# Altium schematic sheet 5V_SYS_SUPPLY.SchDoc
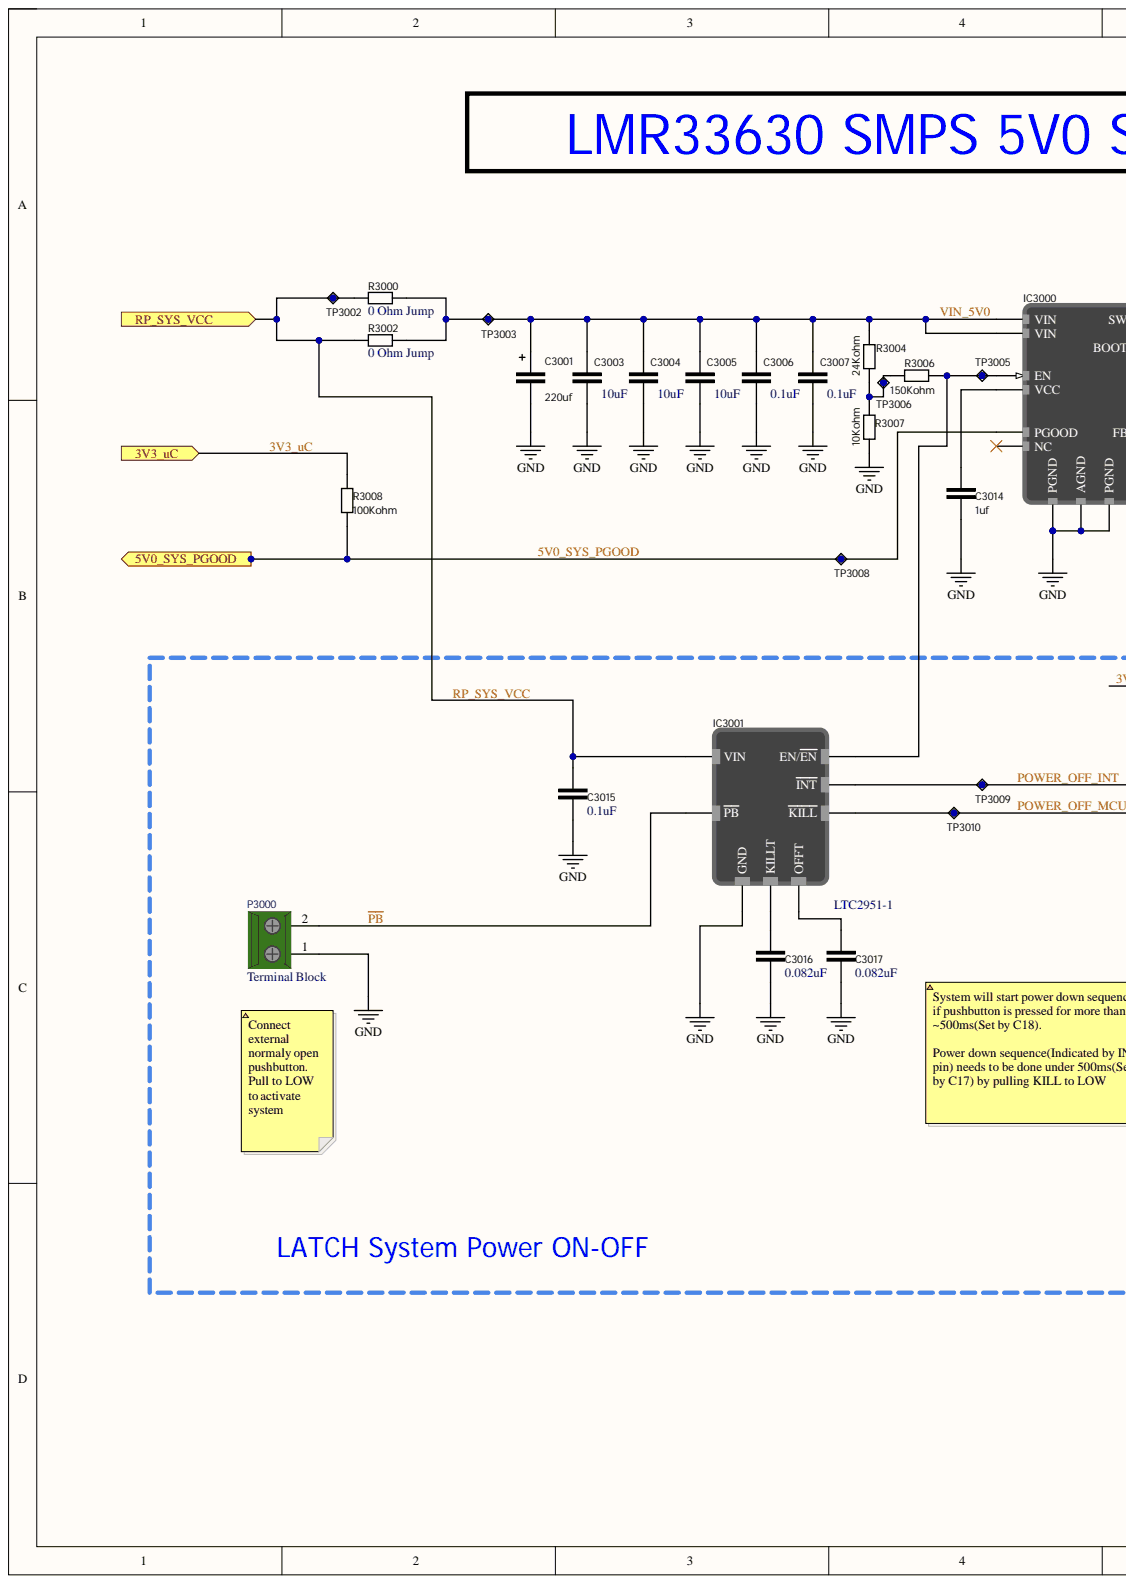
[diagram p0: 5V_SYS_SUPPLY.SchDoc, left]
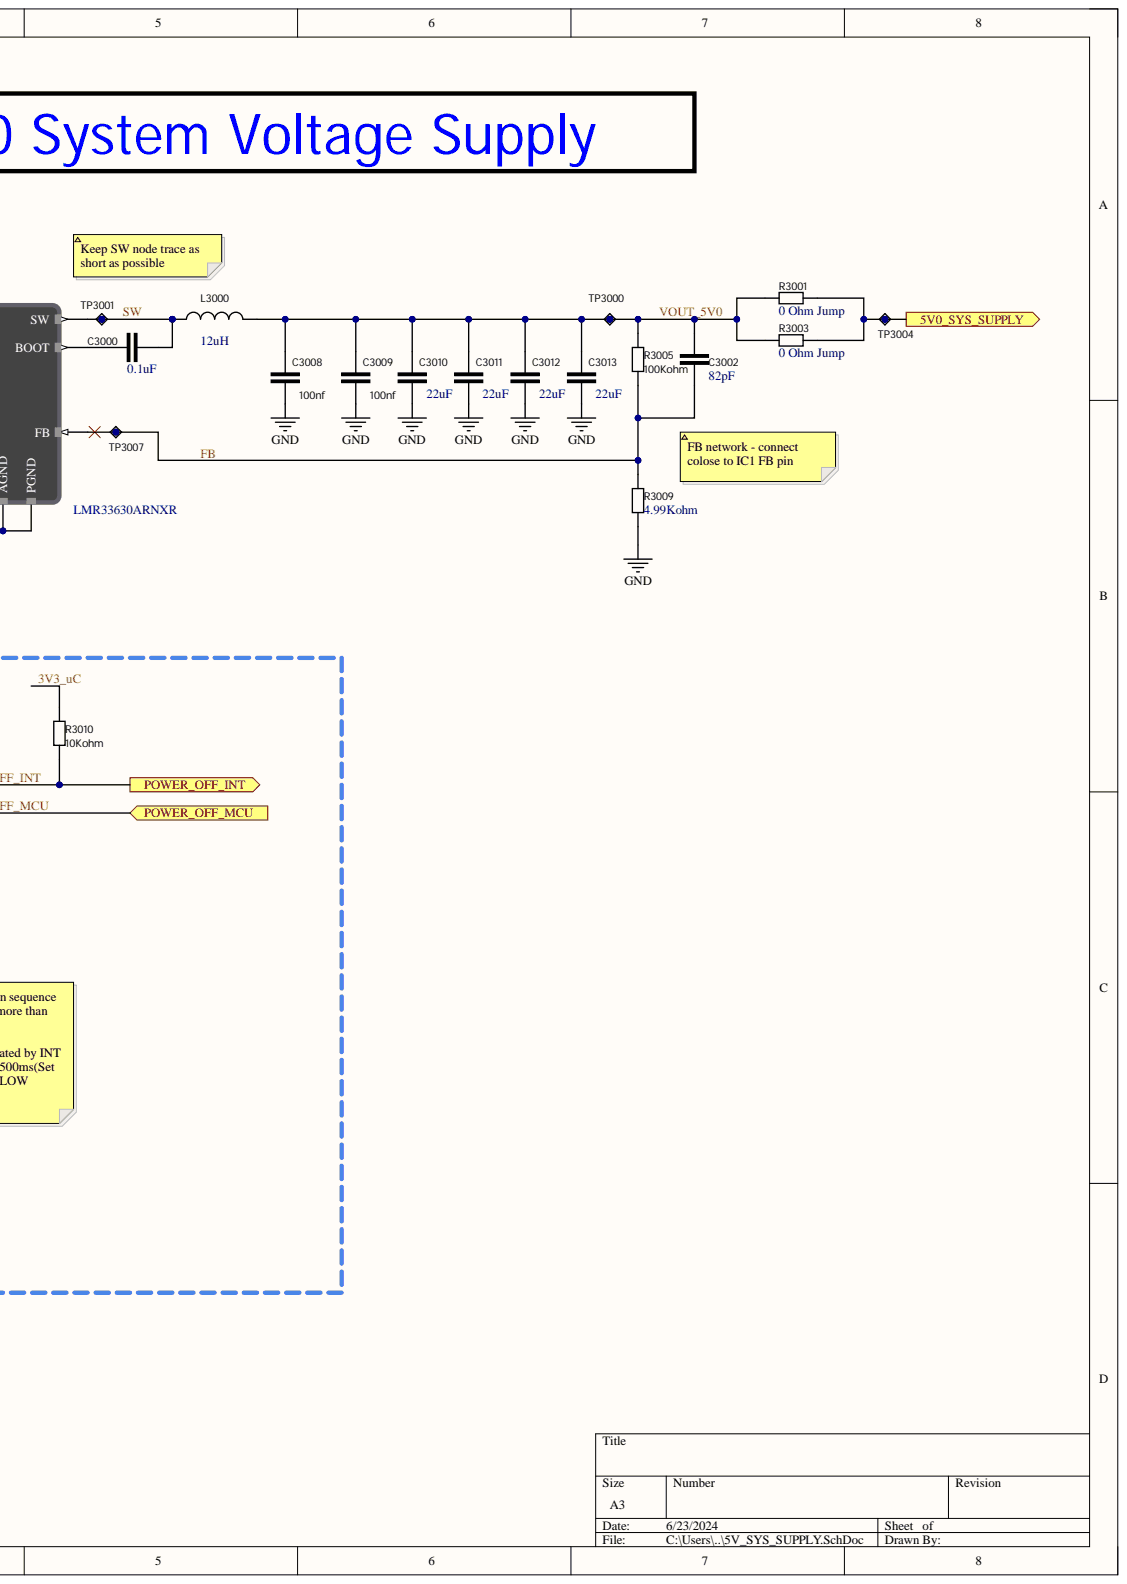
[diagram p1: 5V_SYS_SUPPLY.SchDoc, right]

## Parts
C3000  0.1uF  [CAP_0603]
C3001  220uf  [CAPAE109103X103M]
C3002  82pF  [CAP_0603]
C3003  10uF  [CAP_1206]
C3004  10uF  [CAP_1206]
C3005  10uF  [CAP_1206]
C3006  0.1uF  [CAP_0603]
C3007  0.1uF  [CAP_0603]
C3008  100nf  [CAP_0603]
C3009  100nf  [CAP_0603]
C3010  22uF  [CAP_1206]
C3011  22uF  [CAP_1206]
C3012  22uF  [CAP_1206]
C3013  22uF  [CAP_1206]
C3014  1uf  [CAP_0603]
C3015  0.1uF  [CAP_0603]
C3016  0.082uF  [CAP_0603]
C3017  0.082uF  [CAP_0603]
IC3000  LMR33630ARNXR  [VQFN-HR]
IC3001  LTC2951-1  [TSOP65P280X100-8N]
L3000  12uH  [INDM120120X80N]
P3000  Terminal Block  [TERM_BLOCK 5mm 2PIN]
R3000  0 Ohm Jump  [RES_0805]
R3001  0 Ohm Jump  [RES_1206]
R3002  0 Ohm Jump  [RES_0805]
R3003  0 Ohm Jump  [RES_1206]
R3004  24Kohm  [RES_0603]
R3005  100Kohm  [RES_0603]
R3006  150Kohm  [RES_0603]
R3007  10Kohm  [RES_0603]
R3008  100Kohm  [RES_0603]
R3009  4.99Kohm  [RES_0603]
R3010  10Kohm  [RES_0603]
TP3000  Test point  [TestPoint]  (value not drawn)
TP3001  Test point  [TestPoint]  (value not drawn)
TP3002  Test point  [TestPoint]  (value not drawn)
TP3003  Test point  [TestPoint]  (value not drawn)
TP3004  Test point  [TestPoint]  (value not drawn)
TP3005  Test point  [TestPoint]  (value not drawn)
TP3006  Test point  [TestPoint]  (value not drawn)
TP3007  Test point  [TestPoint]  (value not drawn)
TP3008  Test point  [TestPoint]  (value not drawn)
TP3009  Test point  [TestPoint]  (value not drawn)
TP3010  Test point  [TestPoint]  (value not drawn)

## Ports
3V3_uC, 5V0_SYS_PGOOD, 5V0_SYS_SUPPLY, POWER_OFF_INT, POWER_OFF_MCU, RP_SYS_VCC

## Nets
GND: C3001.1 C3003.1 C3004.1 C3005.1 C3006.1 C3007.1 C3008.1 C3009.1 C3010.1 C3011.1 C3012.1 C3013.1 C3014.1 C3015.1 C3016.1 C3017.1 IC3000.1(PGND) IC3000.6(AGND) IC3000.11(PGND) IC3001.4(GND) P3000.1 R3007.1 R3009.1
3V3_uC: R3008.2 R3010.2 ; port 3V3_uC
5V0_SYS_PGOOD: IC3000.8(PGOOD) R3008.1 TP3008.1 ; port 5V0_SYS_PGOOD
5V0_SYS_SUPPLY: R3001.1 R3003.2 TP3004.1 ; port 5V0_SYS_SUPPLY
FB: C3002.1 IC3000.7(FB) R3005.1 R3009.2 TP3007.1
~{PB}: IC3001.2(PB) P3000.2
POWER_OFF_INT: IC3001.5(INT) R3010.1 TP3009.1 ; port POWER_OFF_INT
POWER_OFF_MCU: IC3001.8(KILL) TP3010.1 ; port POWER_OFF_MCU
RP_SYS_VCC: C3015.2 IC3001.1(VIN) R3000.1 R3002.1 TP3002.1 ; port RP_SYS_VCC
SW: C3000.2 IC3000.12(SW) L3000.1 TP3001.1
VIN_5V0: C3001.2 C3003.2 C3004.2 C3005.2 C3006.2 C3007.2 IC3000.2(VIN) IC3000.10(VIN) R3000.2 R3002.2 R3004.2 TP3003.1
VOUT_5V0: C3002.2 C3008.2 C3009.2 C3010.2 C3011.2 C3012.2 C3013.2 L3000.2 R3001.2 R3003.1 R3005.2 TP3000.1
NetC3000_1: C3000.1 IC3000.4(BOOT)
NetC3014_2: C3014.2 IC3000.5(VCC)
NetC3016_2: C3016.2 IC3001.3(KILLT)
NetC3017_2: C3017.2 IC3001.7(OFFT)
NetIC3000_9: IC3000.9(EN) IC3001.6(EN/EN) R3006.2 TP3005.1
NetR3004_1: R3004.1 R3006.1 R3007.2 TP3006.1

Not connected: IC3000 3(NC)

## Library data of the parts
C3000 C3006 C3007 C3015 = C000018: CAP CER 0.1UF 50V X7R 0603; capacitance: 0.1uF; Component Kind: Standard; ComponentLink1Description: DigiKey; ComponentLink1URL: https://www.digikey.com/en/products/detail/samsung-electro-mechanics/CL10B104KB8NNNC/3886658; DigiKey Part Number: 1276-1000-1-ND; Library Name: CapacitorsIntegrated.IntLib
C3001 = C000019: CAP ALUM 220UF 20% 50V SMD; capaticanse: 220uf; Component Kind: Standard; ComponentLink1Description: digikey; ComponentLink1URL: https://www.digikey.com/en/products/detail/nichicon/UCW1H221MNL1GS/2549783; DigiKey part number: 493-9426-1-ND; Library Name: CapacitorsIntegrated.IntLib
C3002 = C000021: 82 pF ±1% 25V Ceramic Capacitor C0G, NP0 0603 (1608 Metric); capacitance: 82pF; Component Kind: Standard; ComponentLink1Description: DigiKey; ComponentLink1URL: https://www.digikey.com/en/products/detail/kyocera-avx/KGM15ACG1E820FT/1599315?s=N4IgjCBcoMxaBjKAzAhgGwM4FMA0IB7KAbXDBggF18AHAFyhAGU6AnASwDsBzEAX3xgALPBBJIaLHkIlwAVgCcAJiEB2ENRD1GLDj36CAbKPGSc%2BIpFIxlQ45u2RmbLrwEglABiEKTKDOYyViAAHEoABDQAYhq0DE4AqpzsdADyyACy2KiYAK6s2AYgALRKfpBsudKWpHIafA1AA; DigiKey Part Number: 478-KGM15ACG1E820FTCT-ND; Library Name: CapacitorsIntegrated.IntLib
C3003-C3005 = C000017: CAP CER 10UF 50V X7R 1206; capacitance: 10uF; Component Kind: Standard; ComponentLink1Description: DigiKey; ComponentLink1URL: https://www.digikey.com/en/products/detail/samsung-electro-mechanics/CL31B106KBHNNNE/5961251; DigiKey Part Number: 1276-6767-1-ND; Library Name: CapacitorsIntegrated.IntLib
C3008 C3009 = C000007: CAP CER 100nF 0603 25V X7R; capaticanse: 100nf; Component Kind: Standard; ComponentLink1Description: digikey; ComponentLink1URL: https://www.digikey.com/en/products/detail/kyocera-avx/06033C104K4T2A/8019250; Library Name: CapacitorsIntegrated.IntLib
C3010-C3013 = C000020: 22 µF ±10% 25V Ceramic Capacitor X5R 1206 (3216 Metric); capacitance: 22uF; Component Kind: Standard; ComponentLink1Description: DigiKey; ComponentLink1URL: https://www.digikey.com/en/products/detail/samsung-electro-mechanics/CL31A226KAHNNNE/3888705; DigiKey Part Number: 1276-3047-1-ND; Library Name: CapacitorsIntegrated.IntLib
C3014 = C000011: CAP CER 1uF 10V X7R 0603; capaticanse: 1uf; Component Kind: Standard; ComponentLink1Description: digikey; ComponentLink1URL: https://www.digikey.com/en/products/detail/samsung-electro-mechanics/CL10B105KP8NNNC/3887604; DigiKey part number: 1276-1946-2-ND; Library Name: CapacitorsIntegrated.IntLib
C3016 C3017 = C000022: 0.082 µF ±10% 25V Ceramic Capacitor X7R 0603 (1608 Metric); capacitance: 0.082uF; Component Kind: Standard; ComponentLink1Description: DigiKey; ComponentLink1URL: https://www.digikey.com/en/products/detail/kemet/C0603C823K3RAC7867/2201254; DigiKey Part Number: 399-C0603C823K3RAC7867CT-ND; Library Name: CapacitorsIntegrated.IntLib
IC3000 = LMR33630ARNXR: Buck Switching Regulator IC Positive Adjustable 1V 1 Output 3A 12-VFQFN; Component Kind: Standard; ComponentLink1Description: DigiKey; ComponentLink1URL: https://www.digikey.com/en/products/detail/texas-instruments/LMR33630ARNXR/9356577; ComponentLink2Description: Mouser; ComponentLink2URL: https://eu.mouser.com/ProductDetail/Texas-Instruments/LMR33630ARNXR?qs=55YtniHzbhBobt6bGtLStw%3D%3D; DigiKey Part Number: 296-49764-1-ND; Library Name: IntegratedCircuits.IntLib; Mouser Part Number: 595-LMR33630ARNXR
IC3001 = LTC2951-1: Power Supply Controller Push Button, On/Off Controller TSOT-23-8; Component Kind: Standard; ComponentLink1Description: DigiKey; ComponentLink1URL: https://www.digikey.com/en/products/detail/analog-devices-inc/LTC2951CTS8-1-TRMPBF/1620485; ComponentLink2Description: Mouser; ComponentLink2URL: https://eu.mouser.com/ProductDetail/Analog-Devices/LTC2951CTS8-1TRMPBF?qs=hVkxg5c3xu8aoLmrY09Smg%3D%3D; DigiKey Part Number: 505-LTC2951CTS8-1#TRMPBFCT-ND; Library Name: IntegratedCircuits.IntLib; Mouser Part Number: 584-C2951CTS8-1TMPF
L3000 = L000007: FIXED IND 12UH 5.9A 24 MOHM SMD; Component Kind: Standard; ComponentLink1Description: DigiKey; ComponentLink1URL: https://www.digikey.com/en/products/detail/w%C3%BCrth-elektronik/744770112/1638629; DigiKey Part Number: 732-1224-1-ND; inductance: 12uH; Library Name: InductorIntegrated.IntLib
P3000 = 00000001: Terminal block 90 deg 5mm 2PIN; Component Kind: Standard; ComponentLink1Description: DigiKey; ComponentLink1URL: https://www.digikey.com/en/products/detail/adam-tech/EB20-02-C/9831508; DigiKey part number: 2057-EB20-02-C-ND; Library Name: ElectroMechanical.IntLib
R3000 R3002 = R000011: 0 Ohms Jumper Chip Resistor 0805 (2012 Metric) Automotive AEC-Q200 Thick Film; Component Kind: Standard; ComponentLink1Description: DigiKey; ComponentLink1URL: https://www.digikey.com/en/products/detail/panasonic-electronic-components/ERJ-6GEY0R00V/78163; DigiKey Part Number: P0.0ACT-ND; Library Name: ResistorsIntegrated.IntLib; resistance: 0 Ohm Jump
R3001 R3003 = R000012: 0 Ohms Jumper Chip Resistor 1206 (3216 Metric) Automotive AEC-Q200 Thick Film; Component Kind: Standard; ComponentLink1Description: DigiKey; ComponentLink1URL: https://www.digikey.com/en/products/detail/stackpole-electronics-inc/RMCF1206ZT0R00/1756906; DigiKey Part Number: RMCF1206ZT0R00CT-ND; Library Name: ResistorsIntegrated.IntLib; resistance: 0 Ohm Jump
R3004 = R000005: RES 24K OHM 1% 1/10W 0603; Component Kind: Standard; ComponentLink1Description: digikey; ComponentLink1URL: https://www.digikey.com/en/products/detail/stackpole-electronics-inc/RMCF0603FG24K0/1713826; Library Name: ResistorsIntegrated.IntLib; resistance: 24Kohm
R3005 R3008 = R000006: RES 100K OHM 1% 1/10W 0603; Component Kind: Standard; ComponentLink1Description: digikey; ComponentLink1URL: https://www.digikey.com/en/products/detail/stackpole-electronics-inc/RMCF0603FG100K/1713543; Library Name: ResistorsIntegrated.IntLib; resistance: 100Kohm
R3006 = R000002: RES 150K OHM 1% 1/10W 0603; Component Kind: Standard; ComponentLink1Description: digikey; ComponentLink1URL: https://www.digikey.com/en/products/detail/stackpole-electronics-inc/RMCF0603FT150K/1761318; Library Name: ResistorsIntegrated.IntLib; resistance: 150Kohm
R3007 R3010 = R000001: RES 10K OHM 1% 1/10W 0603; Component Kind: Standard; ComponentLink1Description: digikey; ComponentLink1URL: https://www.digikey.com/en/products/detail/vishay-beyschlag-draloric-bc-components/MCT06030C1002FP500/1850440; Library Name: ResistorsIntegrated.IntLib; resistance: 10Kohm
R3009 = R000009: 4.99 kOhms ±1% 0.1W, 1/10W Chip Resistor 0603 (1608 Metric) Automotive AEC-Q200 Thick Film; Component Kind: Standard; ComponentLink1Description: DigiKey; ComponentLink1URL: https://www.digikey.com/en/products/detail/stackpole-electronics-inc/RMCF0603FT4K99/1761322; DigiKey Part Number: RMCF0603FT4K99CT-ND; Library Name: ResistorsIntegrated.IntLib; resistance: 4.99Kohm
TP3000-TP3010 = TP002: Test point 1mm; Component Kind: Standard; Library Name: IntegratedCircuits.IntLib
